# Altium schematic sheet Power_onboard.SchDoc(Power_onboard)
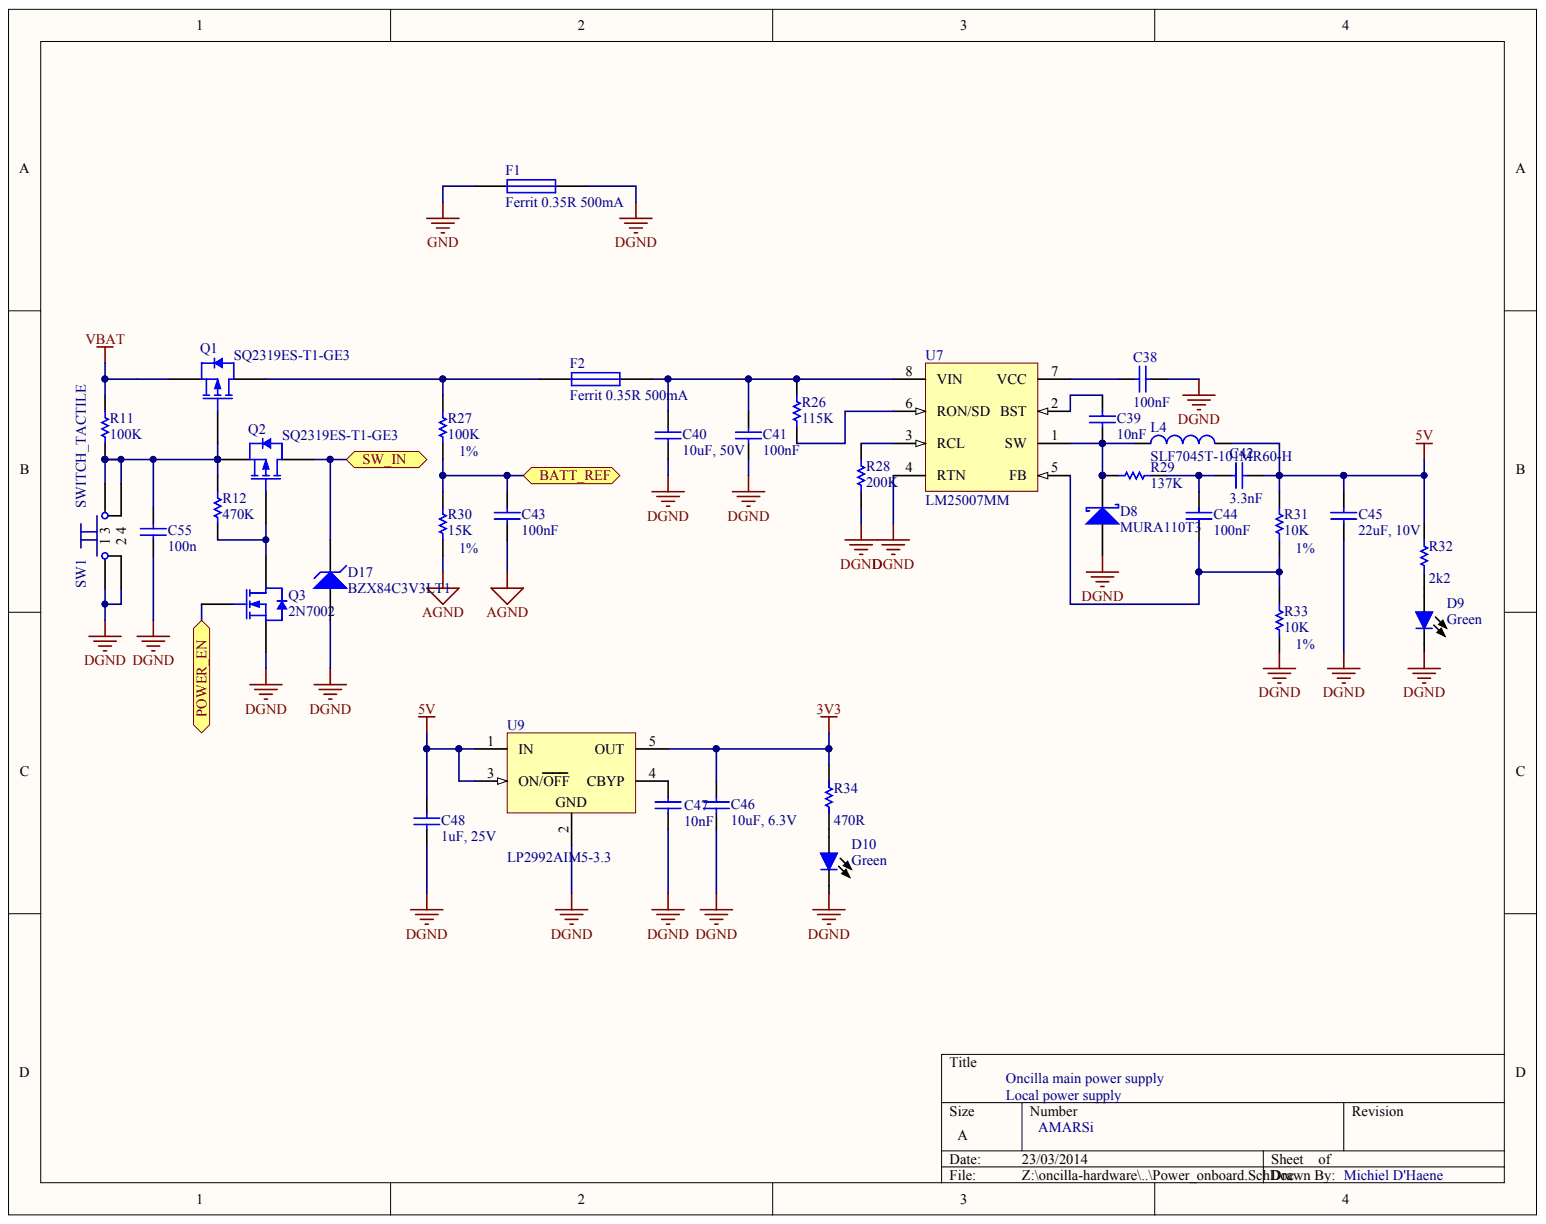
[diagram p0: Power_onboard.SchDoc]

## Parts
C38  100nF
C39  10nF
C40  10uF, 50V
C41  100nF
C42  3.3nF
C43  100nF
C44  value 100nF  (hidden comment: 22nF)
C45  22uF, 10V
C46  10uF, 6.3V
C47  10nF
C48  1uF, 25V
C55  100n
D8  MURA110T3
D9  Color: Green  (hidden comment: LED Green)
D10  Color: Green  (hidden comment: LED Green)
D17  BZX84C3V3LT1
F1  Ferrit 0.35R 500mA
F2  Ferrit 0.35R 500mA
L4  SLF7045T-101MR60-H
Q1  SQ2319ES-T1-GE3
Q2  SQ2319ES-T1-GE3
Q3  2N7002
R11  100k
R12  value 470K  (hidden comment: 100k)
R26  115k
R27  value 100K  Precision: 1%  (hidden comment: 100k, 1%)
R28  200k
R29  value 137K  (hidden comment: 138k)
R30  value 15K  Precision: 1%  (hidden comment: 15k, 1%)
R31  value 10K  Precision: 1%  (hidden comment: 10k, 1%)
R32  2k2
R33  value 10K  Precision: 1%  (hidden comment: 10k, 1%)
R34  470R
SW1  SWITCH_TACTILE
U7  LM25007MM
U9  LP2992AIM5-3.3

## Ports
BATT_REF, POWER_EN, SW_IN

## Nets
3V3: C46.2 R34.2 U9.5(OUT)
5V: C42.2 C45.2 C48.2 L4.2 R31.2 R32.2 U9.1(IN) U9.3(ON/OFF)
AGND: C43.1 R30.1
DGND: C38.1 C40.1 C41.1 C45.1 C46.1 C47.1 C48.1 C55.1 D8.1 D9.2 D10.2 D17.1 F1.2 Q3.2 R28.1 R33.1 SW1.1 SW1.2 U7.4(RTN) U9.2
GND: F1.1
VBAT: Q1.2 R11.2
BATT_REF: C43.2 R27.1 R30.2 ; port BATT_REF
POWER_EN: Q3.1 ; port POWER_EN
SW_IN: D17.3 Q2.3 ; port SW_IN
NetC38_2: C38.2 U7.7(VCC)
NetC39_1: C39.1 D8.2 L4.1 R29.2 U7.1(SW)
NetC39_2: C39.2 U7.2(BST)
NetC40_2: C40.2 C41.2 F2.2 R26.2 U7.8(VIN)
NetC42_1: C42.1 C44.2 R29.1
NetC44_1: C44.1 R31.1 R33.2 U7.5(FB)
NetC47_2: C47.2 U9.4(CBYP)
NetC55_2: C55.2 Q1.1 Q2.2 R11.1 R12.2 SW1.3 SW1.4
NetD9_1: D9.1 R32.1
NetD10_1: D10.1 R34.1
NetF2_1: F2.1 Q1.3 R27.2
NetQ2_1: Q2.1 Q3.3 R12.1
NetR26_1: R26.1 U7.6(RON/SD)
NetR28_2: R28.2 U7.3(RCL)

## Library data of the parts
D8 = (no symbol name): PackageDocument: revH, May-2002; Published: 17-Sep-2003; DatasheetDocument: May-2002; PackageReference: SMA; ComponentLink1Description: Manufacturer Link; ComponentLink1URL: http://www.irf.com/; ComponentLink2Description: Datasheet; ComponentLink2URL: http://www.irf.com/product-info/datasheets/data/10MQ060N.pdf; Publisher: Altium Limited
D17 = (no symbol name): Note: Pin 2 is not connected and not drawn.; Published: 29-Sep-2000; LatestRevisionDate: 17-Jul-2002; LatestRevisionNote: Re-released for DXP Platform.; PackageReference: 318-07; PackageDescription: SOT-23; 3 Leads; Body 3.0 x 2.5 mm, inc. leads (LxW max); ComponentLink1Description: Manufacturer Link; ComponentLink1URL: http://www.onsemi.com/site/products/landing?taxonomyID=194; ComponentLink2Description: Datasheet; ComponentLink2URL: http://www.onsemi.com/pub/Collateral/BZX84C2V4LT1-D.PDF; Publisher: Altium Limited
U7 = (no symbol name): Manufacturer: National Semiconductor; CompType: MiscPower; PackageTopMarking: ZXTT*SLYB; PowerWise: N; FirstPublished: 4-Dec-2008; DatasheetVersion: Feb-2008; PackageVersion: revF, Apr-2008; PackageReference: MUA08A; PackageDescription: MINI SOIC, .118 x .118 x .034in, 8 Lead, .0256in Pitch; PartNumber: LM25007MM; RoHS: Y; PinCount: 8; DigiKeyPartNumber: LM25007MMCT-ND; Code_JEDEC: MO-187-AA; ComponentLink1Description: LM25007 Datasheet; ComponentLink1URL: http://www.national.com/ds/LM/LM25007.pdf; ComponentLink2Description: LM25007 Web Page; ComponentLink2URL: http://www.national.com/pf/LM/LM25007.html; ComponentLink3Description: MUA08A Package Page; ComponentLink3URL: http://www.national.com/packaging/folders/mua08a.html; Publisher: Altium Limited
U9 = (no symbol name): PartNumber: LP2992AIM5-5.0; Manufacturer: National Semiconductor; CompType: LDO IC; PackageTopMarking: LFFA*XTT; PowerWise: N; Published: 4-Dec-2008; DatasheetVersion: May-2005; PackageVersion: revD, Aug-2008; PackageReference: MF05A; PackageDescription: Molded SOT-23, .115 x .063 x .040 in Body, 5 Lead, .0375 in Pitch; RoHS: O; PinCount: 5; DigiKeyPartNumber: LP2992AIM5-5.0CT-ND; ComponentLink1Description: LP2992 Datasheet; ComponentLink1URL: http://www.national.com/ds/LP/LP2992.pdf; ComponentLink2Description: LP2992 Web Page; ComponentLink2URL: http://www.national.com/pf/LP/LP2992.html; ComponentLink3Description: MF05A Package Page; ComponentLink3URL: http://www.national.com/packaging/folders/mf05a.html; Publisher: Altium Limited
C38-C48 C55 D9 D10 F1 F2 L4 Q1-Q3 R11 R12 R26-R34 SW1 = (no symbol name)
  C38 C41 C43: Published: 8-Jun-2000; LatestRevisionDate: 29-May-2009; LatestRevisionNote: IPC-7351 Footprint Added.; PackageReference: C1206; PackageDescription: Chip Capacitor; Value: 100nF
  C39 C47: Published: 8-Jun-2000; LatestRevisionDate: 29-May-2009; LatestRevisionNote: IPC-7351 Footprint Added.; PackageReference: C1206; PackageDescription: Chip Capacitor; Value: 10nF
  C40: Published: 8-Jun-2000; LatestRevisionDate: 29-May-2009; LatestRevisionNote: IPC-7351 Footprint Added.; PackageReference: C1206; PackageDescription: Chip Capacitor; Value: 10uF, 50V
  C42: Published: 8-Jun-2000; LatestRevisionDate: 29-May-2009; LatestRevisionNote: IPC-7351 Footprint Added.; PackageReference: C1206; PackageDescription: Chip Capacitor; Value: 3.3nF
  C44: Published: 8-Jun-2000; LatestRevisionDate: 29-May-2009; LatestRevisionNote: IPC-7351 Footprint Added.; PackageReference: C1206; PackageDescription: Chip Capacitor
  C45: Published: 8-Jun-2000; LatestRevisionDate: 29-May-2009; LatestRevisionNote: IPC-7351 Footprint Added.; PackageReference: C1206; PackageDescription: Chip Capacitor; Value: 22uF, 10V
  C46: Published: 8-Jun-2000; LatestRevisionDate: 29-May-2009; LatestRevisionNote: IPC-7351 Footprint Added.; PackageReference: C1206; PackageDescription: Chip Capacitor; Value: 10uF, 6.3V
  C48: Published: 8-Jun-2000; LatestRevisionDate: 29-May-2009; LatestRevisionNote: IPC-7351 Footprint Added.; PackageReference: C1206; PackageDescription: Chip Capacitor; Value: 1uF, 25V
  C55: Published: 8-Jun-2000; LatestRevisionDate: 29-May-2009; LatestRevisionNote: IPC-7351 Footprint Added.; PackageReference: C1206; PackageDescription: Chip Capacitor; Value: 100n
  F1 F2: Published: 8-Jun-2000; LatestRevisionDate: 17-Jul-2002; LatestRevisionNote: Re-released for DXP Platform.; PackageReference: PIN-W2/E2.8
  Q1 Q2: Published: 17-Sep-2003; LatestRevisionDate: 12-Dec-2006; LatestRevisionNote: IPC-7351 Footprint Added.; DatasheetVersion: 18-Jun-1997; PackageVersion: May-1999; PackageReference: SOT23; PackageDescription: 3-Pin SOT-23 Package 0.95 mm Pitch, 2.3 mm Lead Span; ComponentLink1Description: Manufacturer Link; ComponentLink1URL: http://www.semiconductors.philips.com/; ComponentLink2Description: Datasheet; ComponentLink2URL: http://www.semiconductors.philips.com/acrobat/datasheets/BSS84_3.pdf
  Q3: Published: 17-Sep-2003; LatestRevisionDate: 12-Dec-2006; LatestRevisionNote: IPC-7351 Footprint Added.; DatasheetVersion: 26-Jul-2000; PackageVersion: May-1999; PackageReference: SOT23; PackageDescription: 3-Pin SOT-23 Package 0.95 mm Pitch, 2.3 mm Lead Span; ComponentLink1Description: Manufacturer Link; ComponentLink1URL: http://www.semiconductors.philips.com/; ComponentLink2Description: Datasheet; ComponentLink2URL: http://www.semiconductors.philips.com/acrobat/datasheets/BST82-03.pdf
  R11: Published: 8-Jun-2000; LatestRevisionDate: 17-Jul-2002; LatestRevisionNote: Re-released for DXP Platform.; PackageReference: AXIAL-0.5; Value: 100K
  R12 R27 R29-R31 R33: Published: 8-Jun-2000; LatestRevisionDate: 17-Jul-2002; LatestRevisionNote: Re-released for DXP Platform.; PackageReference: AXIAL-0.5
  R26: Published: 8-Jun-2000; LatestRevisionDate: 17-Jul-2002; LatestRevisionNote: Re-released for DXP Platform.; PackageReference: AXIAL-0.5; Value: 115K
  R28: Published: 8-Jun-2000; LatestRevisionDate: 17-Jul-2002; LatestRevisionNote: Re-released for DXP Platform.; PackageReference: AXIAL-0.5; Value: 200K
  R32: Published: 8-Jun-2000; LatestRevisionDate: 17-Jul-2002; LatestRevisionNote: Re-released for DXP Platform.; PackageReference: AXIAL-0.5; Value: 2k2
  R34: Published: 8-Jun-2000; LatestRevisionDate: 17-Jul-2002; LatestRevisionNote: Re-released for DXP Platform.; PackageReference: AXIAL-0.5; Value: 470R
  C38-C48 C55 F1 F2 Q1-Q3 R11 R12 R26-R34: Publisher: Altium Limited
